# Altium schematic sheet FRAM.SchDoc
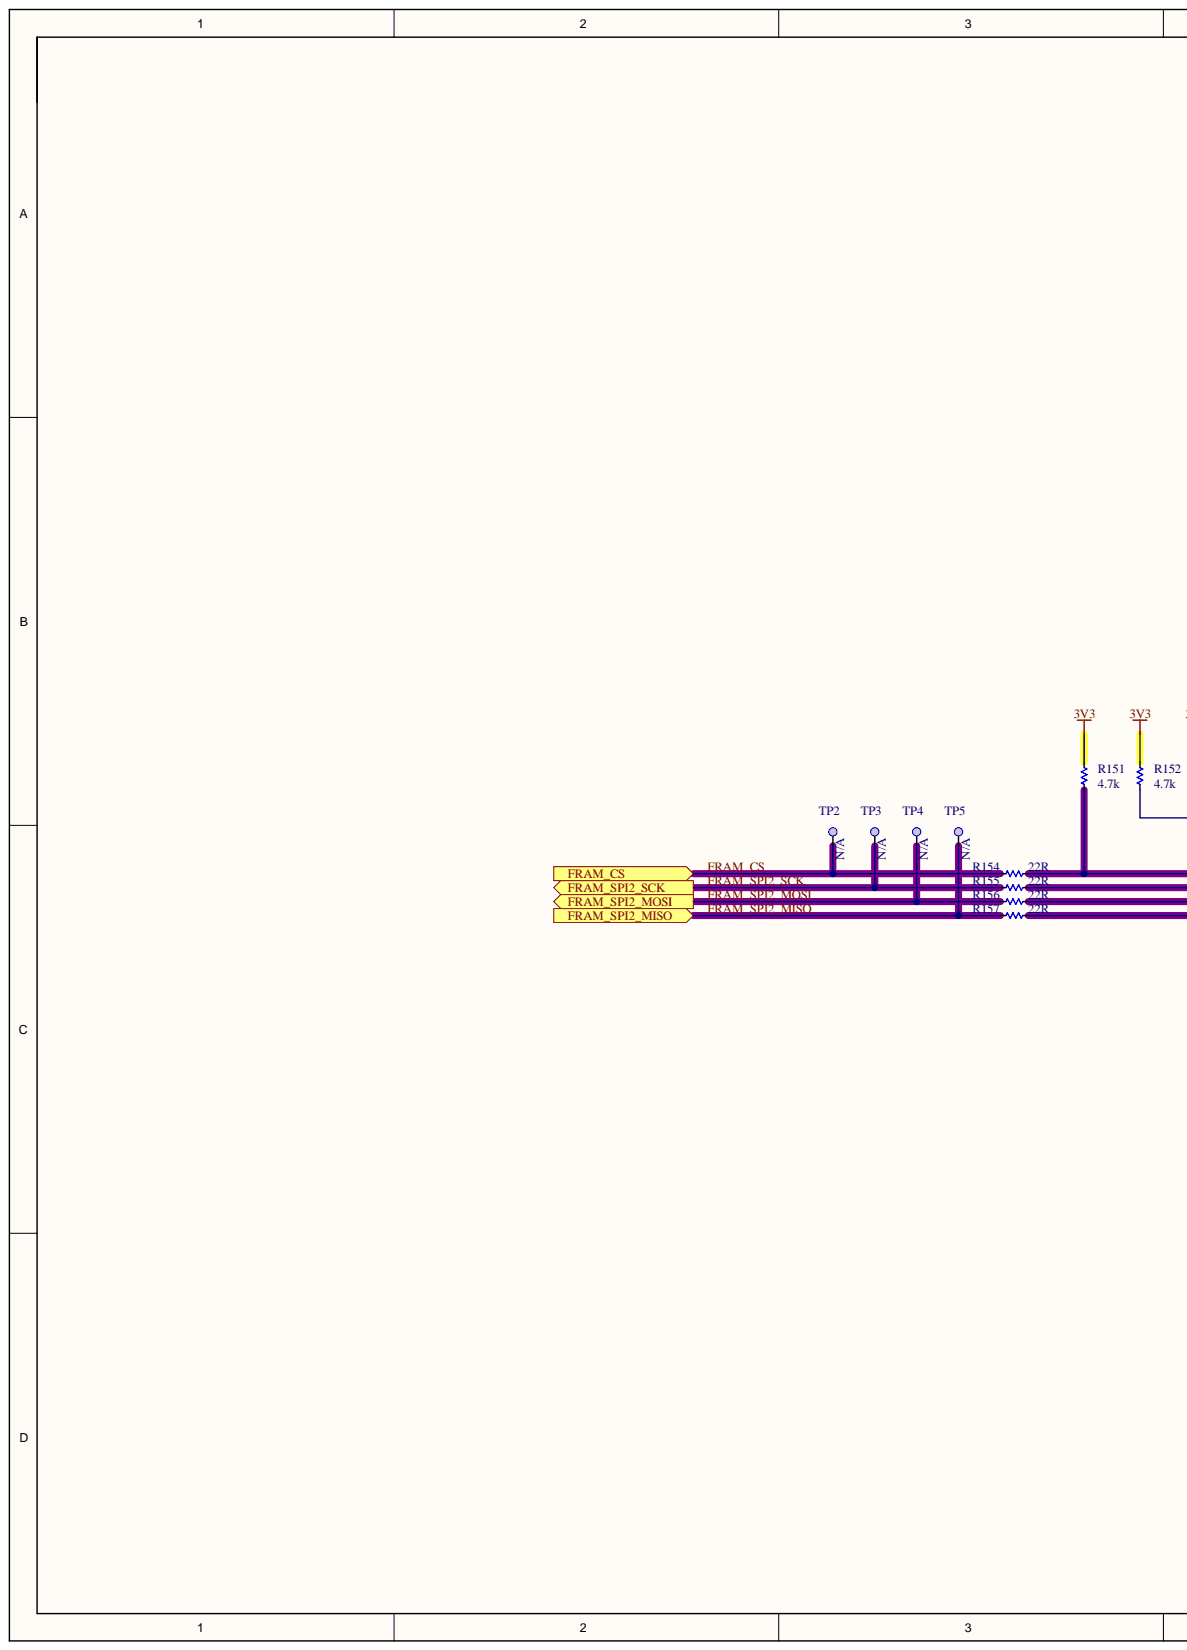
[diagram p0: FRAM.SchDoc, left]
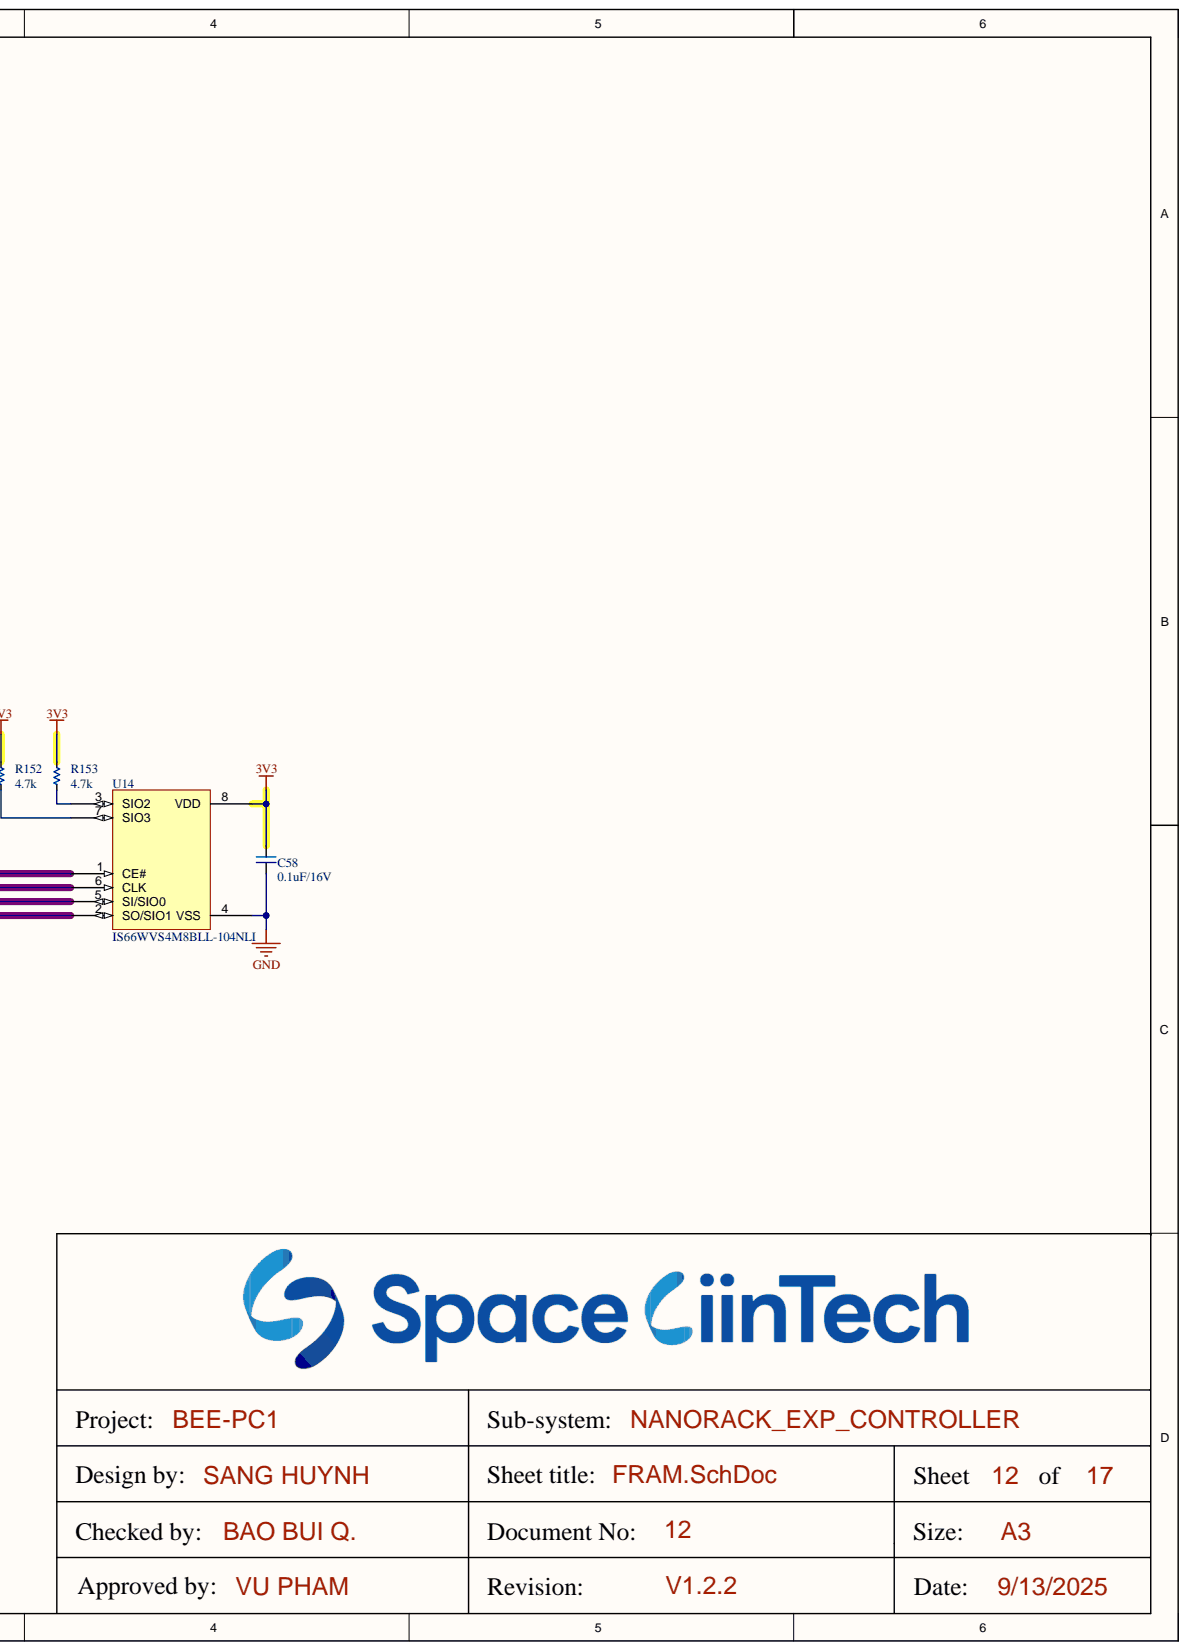
[diagram p1: FRAM.SchDoc, right]

## Parts
C58  0.1uF/16V  [CAP_C_1608X80]
R151  4.7k  [RES_C_1608X60]
R152  4.7k  [RES_C_1608X60]
R153  4.7k  [RES_C_1608X60]
R154  22R  [RES_C_1608X60]
R155  22R  [RES_C_1608X60]
R156  22R  [RES_C_1608X60]
R157  22R  [RES_C_1608X60]
TP2  Test Point  [TESTPOINT]  (value not drawn)  (no BOM)
TP3  Test Point  [TESTPOINT]  (value not drawn)  (no BOM)
TP4  Test Point  [TESTPOINT]  (value not drawn)  (no BOM)
TP5  Test Point  [TESTPOINT]  (value not drawn)  (no BOM)
U14  IS66WVS4M8BLL-104NLI  [SOIC8_150MIL_N_ISI]

## Ports
FRAM_CS, FRAM_SPI2_MISO, FRAM_SPI2_MOSI, FRAM_SPI2_SCK

## Nets
3V3: C58.2 R151.2 R152.2 R153.2 U14.8(VDD)
GND: C58.1 U14.4(VSS)
FRAM_CS: R154.1 TP2.1 ; port FRAM_CS
FRAM_SPI2_MISO: R157.1 TP5.1 ; port FRAM_SPI2_MISO
FRAM_SPI2_MOSI: R156.1 TP4.1 ; port FRAM_SPI2_MOSI
FRAM_SPI2_SCK: R155.1 TP3.1 ; port FRAM_SPI2_SCK
NetR151_1: R151.1 R154.2 U14.1(CE#)
NetR152_1: R152.1 U14.7(SIO3)
NetR153_1: R153.1 U14.3(SIO2)
NetR155_2: R155.2 U14.6(CLK)
NetR156_2: R156.2 U14.5(SI/SIO0)
NetR157_2: R157.2 U14.2(SO/SIO1)

## Library data of the parts
C58 = CAP_SMD: CAP_Chip; Value: 33pF; Component Kind: Standard; Library Name: LABLIB.SchLib
R151-R157 = _RES_B_2: RES; Component Kind: Standard; Library Name: LABLIB.SchLib
TP2-TP5 = MCD-00630: Test Point 0.3mm; Part_Preference: Recommended; Part_Type: Misc; Component_Country_Of_Origin: Unknown; Database Table Name: MCD_PARTS$; ID: 3115; Initial_Board_Reference: MB1264; ShowFields: YES; ComponentLink1URL: http://tunmcdsvn.tun.st.com/Component_Documentation_Library/Trunk; ECCN_EU: n/a; ECCN_US: n/a; Internal_Part_Number: MCD-00630; Library Name: MCD_PARTS_database.DbLib; Manufacturer: N/A; Manufacturer_HQ_COO: Unknown; Manufacturer_Part_Number: N/A; Order_Code_Digikey: N/A; Order_Code_Farnell: N/A; Order_Code_RS: N/A; Provided_By: n/a; Status: Released; Value: N/A
U14 = IS66WVS4M8BLL-104NLI: No Description Available; Manufacturer_Name: ISSI; Component Kind: Standard; Manufacturer_Part_Number: IS66WVS4M8BLL-104NLI; RefDes: RefDes; Type: Memory; Copyright: Copyright (C) 2025 Ultra Librarian. All rights reserved.; Library Name: COMM_SCHLIB.SchLib
